# Altium schematic sheet Sheet1.SchDoc
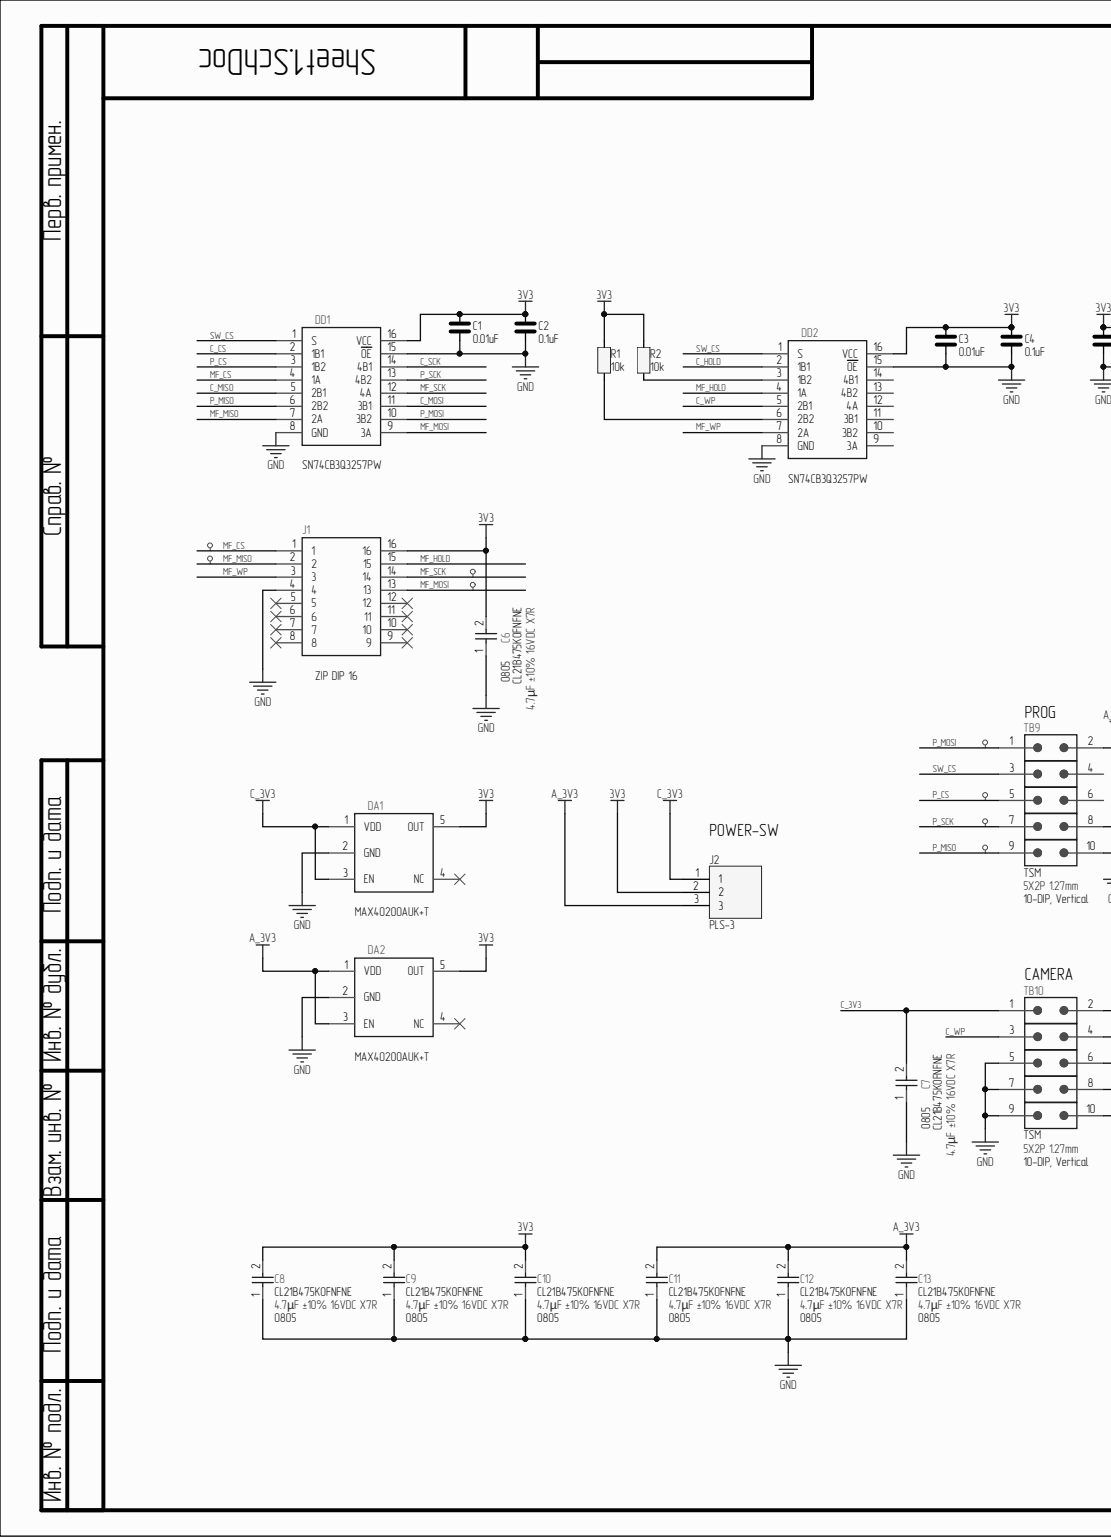
[diagram p0: Sheet1.SchDoc, left]
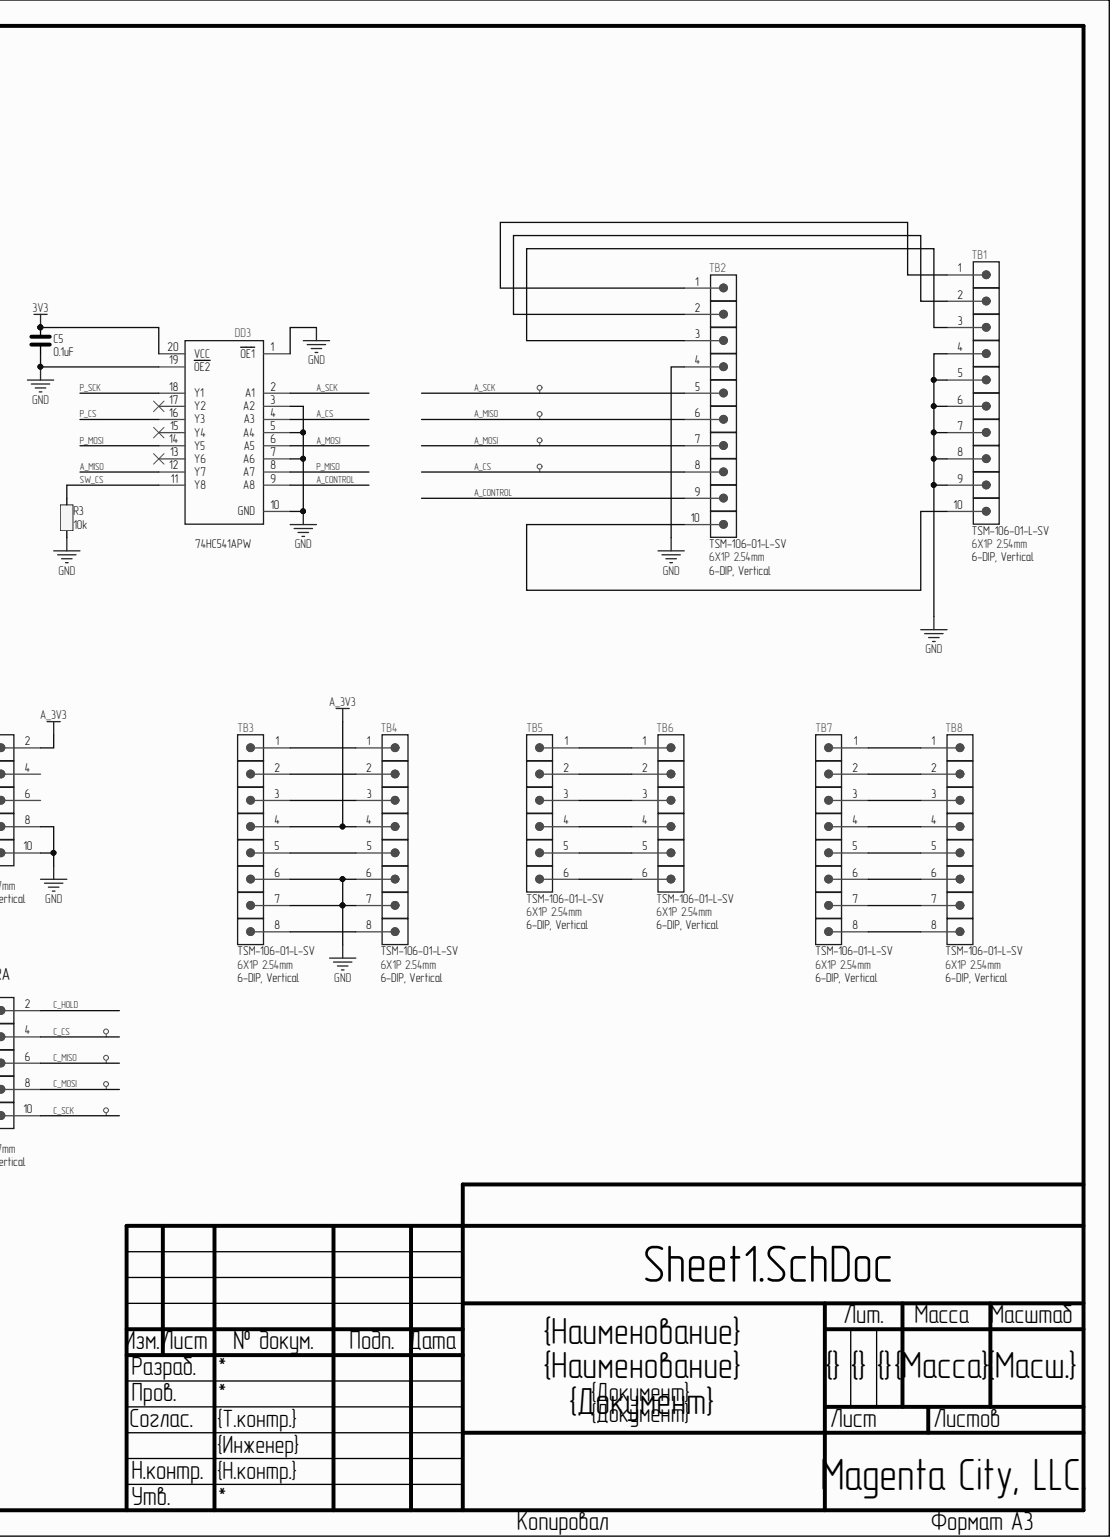
[diagram p1: Sheet1.SchDoc, right]

## Parts
C1  value 0.01uF  [Capacitor 0603 1608]  (hidden comment: 0.01uF 10% 50V X7R)
C2  value 0.1uF  [Capacitor 0603 1608]  (hidden comment: 0.1uF 10% 50V X7R)
C3  value 0.01uF  [Capacitor 0603 1608]  (hidden comment: 0.01uF 10% 50V X7R)
C4  value 0.1uF  [Capacitor 0603 1608]  (hidden comment: 0.1uF 10% 50V X7R)
C5  value 0.1uF  [Capacitor 0603 1608]  (hidden comment: 0.1uF 10% 50V X7R)
C6  CL21B475KOFNFNE  value 4.7µF ±10% 16VDC X7R  Package / Case Code: 0805  [0805_CAP_H1.4mm]
C7  CL21B475KOFNFNE  value 4.7µF ±10% 16VDC X7R  Package / Case Code: 0805  [0805_CAP_H1.4mm]
C8  CL21B475KOFNFNE  value 4.7µF ±10% 16VDC X7R  Package / Case Code: 0805  [0805_CAP_H1.4mm]
C9  CL21B475KOFNFNE  value 4.7µF ±10% 16VDC X7R  Package / Case Code: 0805  [0805_CAP_H1.4mm]
C10  CL21B475KOFNFNE  value 4.7µF ±10% 16VDC X7R  Package / Case Code: 0805  [0805_CAP_H1.4mm]
C11  CL21B475KOFNFNE  value 4.7µF ±10% 16VDC X7R  Package / Case Code: 0805  [0805_CAP_H1.4mm]
C12  CL21B475KOFNFNE  value 4.7µF ±10% 16VDC X7R  Package / Case Code: 0805  [0805_CAP_H1.4mm]
C13  CL21B475KOFNFNE  value 4.7µF ±10% 16VDC X7R  Package / Case Code: 0805  [0805_CAP_H1.4mm]
DA1  MAX40200AUK+T  [SOT23-5]
DA2  MAX40200AUK+T  [SOT23-5]
DD1  SN74CB3Q3257PW  [TSSOP-16-0.65]
DD2  SN74CB3Q3257PW  [TSSOP-16-0.65]
DD3  74HC541APW  [TSSOP-20-0.65]
J1  ZIP DIP 16  Mounting Type: DIP  [DIP-16]
J2  PLS-3  [PLS-3]
R1  value 10k  [Resistor 0603 1608]  (hidden comment: 10k Tolerance)
R2  value 10k  [Resistor 0603 1608]  (hidden comment: 10k Tolerance)
R3  value 10k  [Resistor 0603 1608]  (hidden comment: 10k Tolerance)
TB1  TSM-106-01-L-SV  value 6X1P 2.54mm  Package / Case Code: 6-DIP, Vertical  [SW10]
TB2  TSM-106-01-L-SV  value 6X1P 2.54mm  Package / Case Code: 6-DIP, Vertical  [SW10]
TB3  TSM-106-01-L-SV  value 6X1P 2.54mm  Package / Case Code: 6-DIP, Vertical  [SW8]
TB4  TSM-106-01-L-SV  value 6X1P 2.54mm  Package / Case Code: 6-DIP, Vertical  [SW8]
TB5  TSM-106-01-L-SV  value 6X1P 2.54mm  Package / Case Code: 6-DIP, Vertical  [SW6]
TB6  TSM-106-01-L-SV  value 6X1P 2.54mm  Package / Case Code: 6-DIP, Vertical  [SW6]
TB7  TSM-106-01-L-SV  value 6X1P 2.54mm  Package / Case Code: 6-DIP, Vertical  [SW8]
TB8  TSM-106-01-L-SV  value 6X1P 2.54mm  Package / Case Code: 6-DIP, Vertical  [SW8]
TB9  TSM  value 5X2P 1.27mm  Package / Case Code: 10-DIP, Vertical  [SW10x2_1.27]
TB10  TSM  value 5X2P 1.27mm  Package / Case Code: 10-DIP, Vertical  [SW10x2_1.27]

## Nets
3V3: C1.2 C2.2 C3.2 C4.2 C5.2 C6.2 C8.2 C9.2 C10.2 DA1.5(OUT) DA2.5(OUT) DD1.16(VCC) DD2.16(VCC) DD3.20(VCC) J1.16 J2.2 R1.2 R2.2
A_3V3: C11.2 C12.2 C13.2 DA2.1(VDD) DA2.3(EN) J2.3 TB3.4 TB4.4 TB9.2
GND: C1.1 C2.1 C3.1 C4.1 C5.1 C6.1 C7.1 C8.1 C9.1 C10.1 C11.1 C12.1 C13.1 DA1.2(GND) DA2.2(GND) DD1.8(GND) DD1.15(OE) DD2.8(GND) DD2.15(OE) DD3.1(OE1) DD3.3(A2) DD3.5(A4) DD3.7(A6) DD3.10(GND) DD3.19(OE2) J1.4 R3.1 TB1.4 TB1.5 TB1.6 TB1.7 TB1.8 TB1.9 TB2.4 TB3.6 TB3.7 TB4.6 TB4.7 TB9.8 TB9.10 TB10.5 TB10.7 TB10.9
A_CONTROL: DD3.9(A8) TB2.9
A_CS: DD3.4(A3) TB2.8
A_MISO: DD3.12(Y7) TB2.6
A_MOSI: DD3.6(A5) TB2.7
A_SCK: DD3.2(A1) TB2.5
C_3V3: C7.2 DA1.1(VDD) DA1.3(EN) J2.1 TB10.1
C_CS: DD1.2(1B1) TB10.4
C_HOLD: DD2.2(1B1) TB10.2
C_MISO: DD1.5(2B1) TB10.6
C_MOSI: DD1.11(3B1) TB10.8
C_SCK: DD1.14(4B1) TB10.10
C_WP: DD2.5(2B1) TB10.3
MF_CS: DD1.4(1A) J1.1
MF_HOLD: DD2.4(1A) J1.15
MF_MISO: DD1.7(2A) J1.2
MF_MOSI: DD1.9(3A) J1.13
MF_SCK: DD1.12(4A) J1.14
MF_WP: DD2.7(2A) J1.3
P_CS: DD1.3(1B2) DD3.16(Y3) TB9.5
P_MISO: DD1.6(2B2) DD3.8(A7) TB9.9
P_MOSI: DD1.10(3B2) DD3.14(Y5) TB9.1
P_SCK: DD1.13(4B2) DD3.18(Y1) TB9.7
SW_CS: DD1.1(S) DD2.1(S) DD3.11(Y8) R3.2 TB9.3
NetDD2_3: DD2.3(1B2) R2.1
NetDD2_6: DD2.6(2B2) R1.1
NetTB1_1: TB1.1 TB2.1
NetTB1_2: TB1.2 TB2.2
NetTB1_3: TB1.3 TB2.3
NetTB1_10: TB1.10 TB2.10
NetTB3_1: TB3.1 TB4.1
NetTB3_2: TB3.2 TB4.2
NetTB3_3: TB3.3 TB4.3
NetTB3_5: TB3.5 TB4.5
NetTB3_8: TB3.8 TB4.8
NetTB5_1: TB5.1 TB6.1
NetTB5_2: TB5.2 TB6.2
NetTB5_3: TB5.3 TB6.3
NetTB5_4: TB5.4 TB6.4
NetTB5_5: TB5.5 TB6.5
NetTB5_6: TB5.6 TB6.6
NetTB7_1: TB7.1 TB8.1
NetTB7_2: TB7.2 TB8.2
NetTB7_3: TB7.3 TB8.3
NetTB7_4: TB7.4 TB8.4
NetTB7_5: TB7.5 TB8.5
NetTB7_6: TB7.6 TB8.6
NetTB7_7: TB7.7 TB8.7
NetTB7_8: TB7.8 TB8.8

Not connected: DA1 4(NC); DA2 4(NC); DD2 9(3A) 10(3B2) 11(3B1) 12(4A) 13(4B2) 14(4B1); DD3 13(Y6) 15(Y4) 17(Y2); J1 5 6 7 8 9 10 11 12; TB9 4 6

## Library data of the parts
C1-C5 = Capacitor_0603: SMD 0603 Capacitor; Component Kind: Standard; Dielectric: X7R; DNP: DNP; Library Name: Program.SCHLIB; Package Reference: 0603; Tolerance: 10%; Voltage: 50V
C6 C9-C13 = CL21B475KOFNFNE: CAP CER 0805   4.7µF ±10% 25V X7R; Manufacturer: SAMSUNG; Environmental: RoHS Compliant; Category: CAP CER; Component Kind: Standard; ComponentLink5Description: Product Search; ComponentLink5URL: http://www.samsungsem.com/global/support/product-search/index.jsp; Manufacturer Part Number: CL21B475KOFNFNE; Mounting Type: SMT; Operating Temperature: -55°C to +125°C; Packaging: Tape&Reel; Size / Dimension: 0.079" L x 0.049" W (2.00mm x 1.25mm); Thickness (Max): 0.053" (1.35mm)
  C13: Library Name: Program.SCHLIB
  C6 C9-C12: Library Name: Components_Library_Magenta_City.IntLib
C7 C8 = CL21B475KOFNFNE: CAP CER 0805   4.7µF ±10% 25V X7R; Manufacturer: SAMSUNG; Environmental: RoHS Compliant; Value: 4.7µF ±10% 16VDC X7R; Category: CAP CER; Component Kind: Standard; ComponentLink5Description: Product Search; ComponentLink5URL: http://www.samsungsem.com/global/support/product-search/index.jsp; Manufacturer Part Number: CL21B475KOFNFNE; Mounting Type: SMT; Operating Temperature: -55°C to +125°C; Packaging: Tape&Reel; Size / Dimension: 0.079" L x 0.049" W (2.00mm x 1.25mm); Thickness (Max): 0.053" (1.35mm); Library Name: Components_Library_Magenta_City.IntLib
DA1 DA2 = MAX40200AUK+T: Manufacturer: MAXIM; Base Part Number: MAX40200AUK+T; Category: IC; Component Kind: Standard; ComponentLink1Description: Product Page; ComponentLink1URL: https://www.microchip.com/wwwproducts/en/ATmega328PB; ComponentLink2Description: DataSheet; ComponentLink2URL: http://ww1.microchip.com/downloads/en/DeviceDoc/40001906C.pdf; Library Name: Program.SCHLIB; Manufacturer Base Part Number: MAX40200AUK+T; Manufacturer Part Number: MAX40200AUK+T; Mounting Type: SOT-23-5; Operating Temperature: -40°C to +105°C; Packaging: Tray
DD1 DD2 = SN74CB3Q3257: TSSOP; Manufacturer: TI; Base Part Number: SN74CB3Q3257PW; Category: IC; Component Kind: Standard; ComponentLink1Description: Product Page; ComponentLink1URL: https://www.microchip.com/wwwproducts/en/ATmega328PB; ComponentLink2Description: DataSheet; ComponentLink2URL: http://ww1.microchip.com/downloads/en/DeviceDoc/40001906C.pdf; Library Name: Program.SCHLIB; Manufacturer Base Part Number: SN74CB3Q3257PW; Manufacturer Part Number: SN74CB3Q3257PW; Mounting Type: TSSOP; Operating Temperature: -40°C to +105°C; Packaging: Tray
DD3 = 74HC541APW: Manufacturer: TI; Environmental: RoHS Compliant; Base Part Number: 74HC541APW; Category: IC; Component Kind: Standard; ComponentLink1Description: Product Page; ComponentLink1URL: https://www.microchip.com/wwwproducts/en/ATmega328PB; ComponentLink2Description: DataSheet; ComponentLink2URL: http://ww1.microchip.com/downloads/en/DeviceDoc/40001906C.pdf; Library Name: Program.SCHLIB; Manufacturer Base Part Number: 74HC541APW; Manufacturer Part Number: 74HC541APW; Mounting Type: TSSOP; Operating Temperature: -40°C to +105°C; Packaging: Tray
J1 = ZIP DIP 16: 3M™ Textool™.100” (2.54 mm) DIP Sockets  ZIP DIP; Manufacturer: TI; Environmental: RoHS Compliant; Base Part Number: ZIP DIP 16; Category: IC; Component Kind: Standard; ComponentLink1Description: Product Page; ComponentLink1URL: https://www.microchip.com/wwwproducts/en/ATmega328PB; ComponentLink2Description: DataSheet; ComponentLink2URL: http://ww1.microchip.com/downloads/en/DeviceDoc/40001906C.pdf; Manufacturer Base Part Number: ZIP DIP 16; Manufacturer Part Number: ZIP DIP 16; Operating Temperature: -40°C to +105°C; Packaging: Tray; Library Name: Magenta_City_lib.SchLib
R1-R3 = Resistor_0603: SMD 0603 Resistor; Component Kind: Standard; DNP: DNP; Library Name: Program.SCHLIB; Package Reference: 0603; Tolerance: Tolerance
TB1 TB2 = SW-10: TERMINAL STRIP   2.54mm 6X1P   SMD Vertical; Manufacturer: Samtec; Environmental: RoHS Compliant; Category: TERMINAL STRIP; Component Kind: Standard; ComponentLink1Description: Product Page; ComponentLink1URL: https://www.samtec.com/products/tsm-106-01-l-sv; Manufacturer Part Number: TSM-106-01-L-SV; Mounting Type: SMT; Packaging: Tape&Reel; Library Name: Components_Library_Magenta_City.IntLib
TB3 TB4 TB7 TB8 = SW-8: TERMINAL STRIP   2.54mm 6X1P   SMD Vertical; Manufacturer: Samtec; Environmental: RoHS Compliant; Category: TERMINAL STRIP; Component Kind: Standard; ComponentLink1Description: Product Page; ComponentLink1URL: https://www.samtec.com/products/tsm-106-01-l-sv; Manufacturer Part Number: TSM-106-01-L-SV; Mounting Type: SMT; Packaging: Tape&Reel; Library Name: Components_Library_Magenta_City.IntLib
TB5 TB6 = SW-6: TERMINAL STRIP   2.54mm 6X1P   SMD Vertical; Manufacturer: Samtec; Environmental: RoHS Compliant; Category: TERMINAL STRIP; Component Kind: Standard; ComponentLink1Description: Product Page; ComponentLink1URL: https://www.samtec.com/products/tsm-106-01-l-sv; Manufacturer Part Number: TSM-106-01-L-SV; Mounting Type: SMT; Packaging: Tape&Reel; Library Name: Components_Library_Magenta_City.IntLib
TB9 TB10 = TSM-1: TERMINAL STRIP   1.27mm 5X2P   DIP Vertical; Manufacturer: Samtec; Environmental: RoHS Compliant; Category: TERMINAL STRIP; Component Kind: Standard; ComponentLink1Description: Product Page; ComponentLink1URL: https://www.samtec.com/products/tsm-105-01-l-dv; Manufacturer Part Number: TSM; Mounting Type: DIP; Packaging: Tape&Reel
  TB9: Library Name: Program.SCHLIB
  TB10: Library Name: Components_Library_Magenta_City.IntLib
J2 = PLS-3: Component Kind: Standard; Library Name: Program.SCHLIB
